# Altium schematic sheet H05R1_Frontend_2.SchDoc(H05R1_Frontend_2)
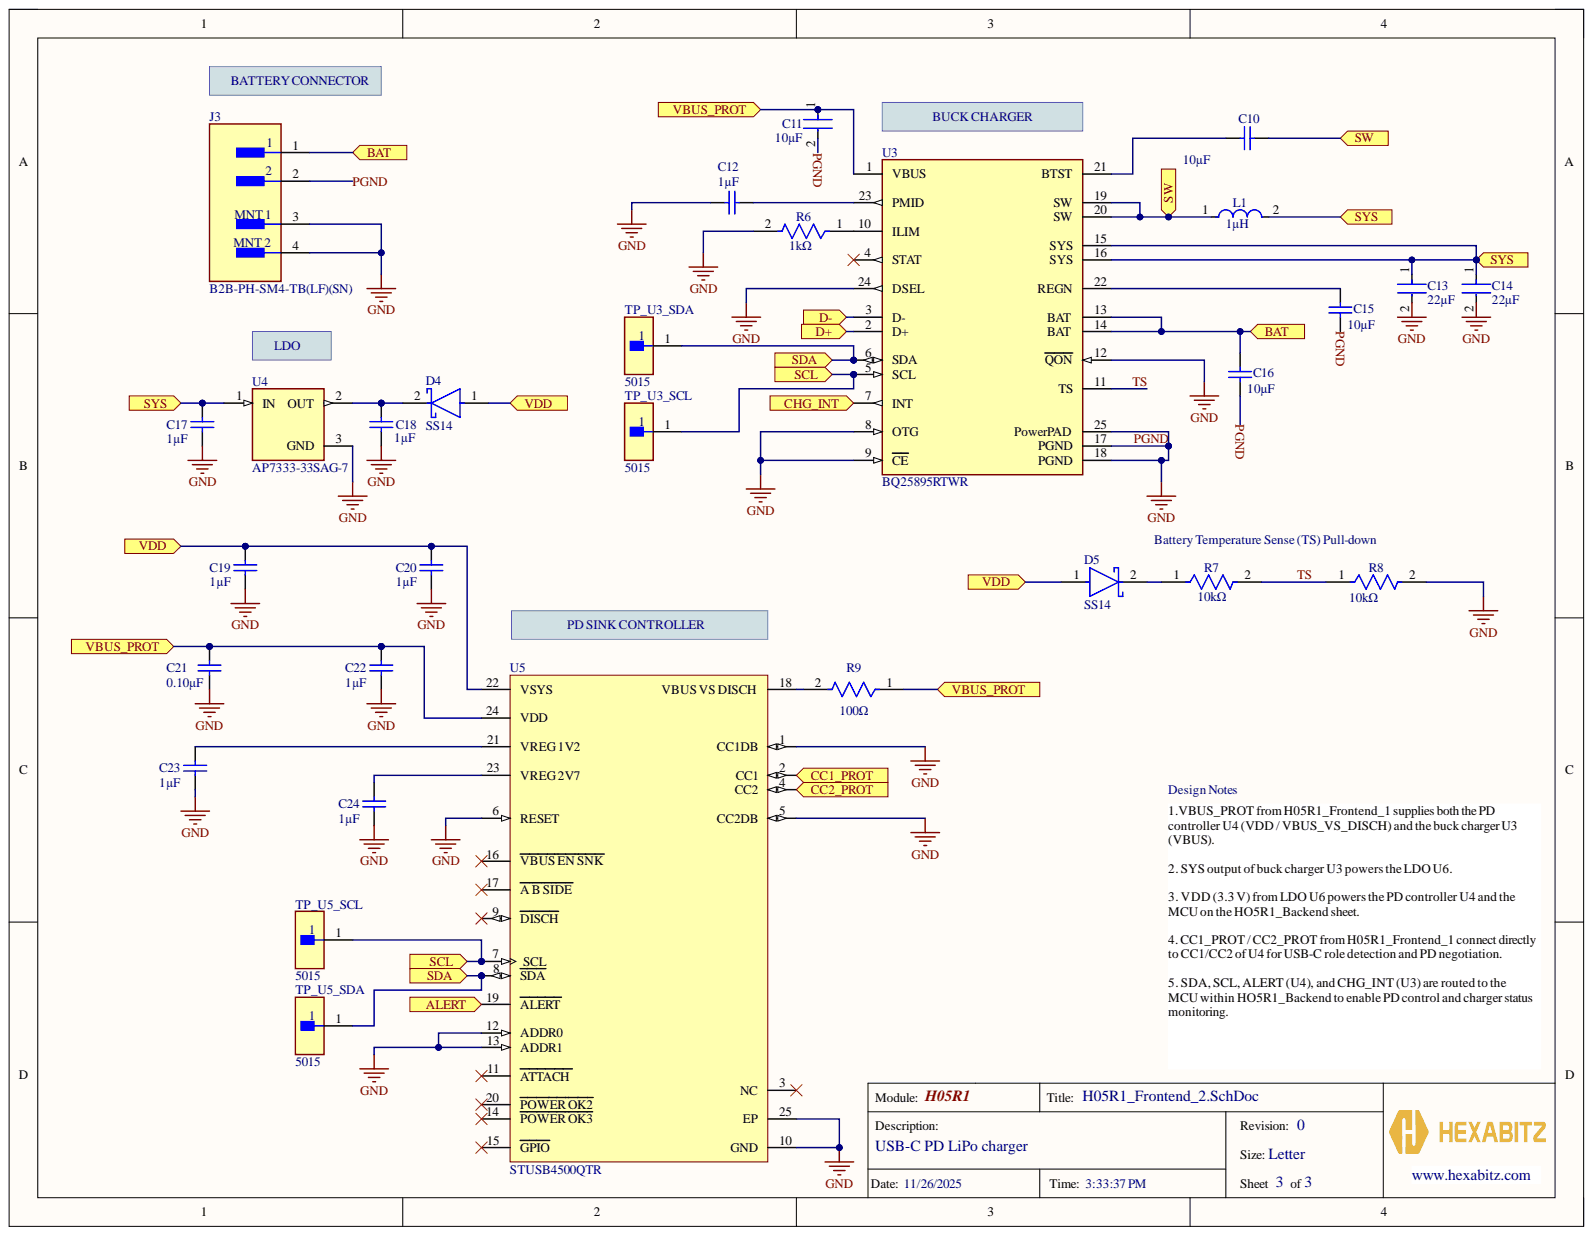
[diagram p0: H05R1_Frontend_2.SchDoc]

## Parts
C10  10µF  [CAPC1608X90X35ML10T15]
C11  10µF  [FP-GRM21B-0_2-e0_2_0_7-IPC_B]
C12  1µF  [CAPC1608X90X35ML10T15]
C13  22µF  [FP-GRM21B-0_15-MFG]
C14  22µF  [FP-GRM21B-0_15-MFG]
C15  10µF  [CAPC1608X90X35ML10T15]
C16  10µF  [CAPC2013X135X45ML10T25]
C17  1µF  [CAPC1608X90X35ML10T15]
C18  1µF  [CAPC1608X90X35ML10T15]
C19  1µF  [MURA-0603_H_0.9_M]
C20  1µF  [CAPC1608X90X35ML10T15]
C21  0.10μF  [CAPC1608X90X35LL10T15]
C22  1µF  [CAPC1608X90X35ML10T15]
C23  1µF  [CAPC1608X90X35ML10T15]
C24  1µF  [CAPC1608X90X35ML10T15]
D4  SS14  [FP-403AE-MFG]
D5  SS14  [FP-403AE-MFG]
J3  B2B-PH-SM4-TB(LF)(SN)  [FP-B2B-PH-SM4-TB_LF_SN-MFG]
L1  1µH  [FP-XAL4020-102MEC-MFG]
R6  1kΩ  [FP-RC0603-0_55-IPC_C]
R7  10kΩ  [FP-RC0603-0_55-IPC_C]
R8  10kΩ  [FP-RC0603-0_55-IPC_C]
R9  100Ω  [FP-RC0603-0_55-MFG]
TP_U3_SCL  5015  [FP-5015-MFG]
TP_U3_SDA  5015  [FP-5015-MFG]
TP_U5_SCL  5015  [FP-5015-MFG]
TP_U5_SDA  5015  [FP-5015-MFG]
U3  BQ25895RTWR  [FP-RTW0024H-IPC_B]
U4  AP7333-33SAG-7  [FP-SOT23-MFG]
U5  STUSB4500QTR  [FP-QFN-24-EP_4x4-MFG]

## Ports
ALERT, BAT, CC1_PROT, CC2_PROT, CHG_INT, D+, D-, SCL, SDA, SW, SYS, VBUS_PROT, VDD

## Nets
ALERT: U5.19(ALERT) ; port ALERT
BAT: C16.2 J3.1 U3.13(BAT) U3.14(BAT) ; port BAT
CC1_PROT: U5.2(CC1) ; port CC1_PROT
CC2_PROT: U5.4(CC2) ; port CC2_PROT
CHG_INT: U3.7(INT) ; port CHG_INT
PGND: C11.2 C12.1 C13.2 C14.2 C15.1 C16.1 C17.1 C18.1 C19.1 C20.1 C21.1 C22.1 C23.1 C24.1 J3.2 J3.3 J3.4 R6.2 R8.2 U3.8(OTG) U3.9(CE) U3.12(QON) U3.17(PGND) U3.18(PGND) U3.24(DSEL) U3.25(PowerPAD) U4.3 U5.1(CC1DB) U5.5(CC2DB) U5.6(RESET) U5.10(GND) U5.12(ADDR0) U5.13(ADDR1) U5.25(EP)
SCL: TP_U3_SCL.1 TP_U5_SCL.1 U3.5(SCL) U5.7(SCL) ; port SCL
SDA: TP_U3_SDA.1 TP_U5_SDA.1 U3.6(SDA) U5.8(SDA) ; port SDA
SW: C10.1 L1.1 U3.19(SW) U3.20(SW) ; port SW
SYS: C13.1 C14.1 C17.2 L1.2 U3.15(SYS) U3.16(SYS) U4.1 ; port SYS
TS: R7.2 R8.1 U3.11(TS)
VBUS_PROT: C11.1 C21.2 C22.2 R9.1 U3.1(VBUS) U5.24(VDD) ; port VBUS_PROT
VDD: C19.2 C20.2 D4.1 D5.1 U5.22(VSYS) ; port VDD
NetC10_2: C10.2 U3.21(BTST)
NetC12_2: C12.2 U3.23(PMID)
NetC15_2: C15.2 U3.22(REGN)
NetC18_2: C18.2 D4.2 U4.2
NetC23_2: C23.2 U5.21(VREG_1V2)
NetC24_2: C24.2 U5.23(VREG_2V7)
NetD5_2: D5.2 R7.1
NetR6_1: R6.1 U3.10(ILIM)
NetR9_2: R9.2 U5.18(VBUS_VS_DISCH)
NetU3_2: U3.2(D+)
NetU3_3: U3.3(D-)

Not connected: U3 4(STAT); U5 3(NC) 9(DISCH) 11(ATTACH) 14(POWER_OK3) 15(GPIO) 16(VBUS_EN_SNK) 17(A_B_SIDE) 20(POWER_OK2)

## Library data of the parts
C10 = CMP-2006-02858-1: Ceramic Capacitor, Multilayer, Ceramic, 25V, 10% +Tol, 10% -Tol, X7R, 15% TC, 10uF, Surface Mount, 0805; Manufacturer: Murata; Part Number: GRM188R71C473KA01D; Component Kind: Standard; Library Name: Altium Content Vault; Octopart: https://octopart.com/part/murata/GRM21BZ71E106KE15L
C11 = CMP-06035-047417-1: Chip Multilayer Ceramic Capacitors for General Purpose, 0805, 10uF, X7R, 15%, 10%, 25V; MPN: GRM21BZ71E106KE15L; MFN: Murata; Component Kind: Standard; Library Name: Altium Content Vault; Parameter 1: https://octopart.com/part/murata/GRM21BZ71E106KE15L
C12 = CMP-2006-02845-1: Ceramic Capacitor, Ceramic, 16V, 10% +Tol, 10% -Tol, X7R, 15% TC, 1uF, Surface Mount, 0603  Series: Murata - Chip Monolithic Ceramic Capacitors; Part Number: GRM188R71C105KA12D; Component Kind: Standard; Library Name: Altium Content Vault; Manufacturer: Murata; Octopart: https://octopart.com/search?q=GRM188R71C105KA12D&currency=USD&specs=0
C13 C14 = CMP-2007-03385-2: Chip Multilayer Ceramic Capacitors for General Purpose, 0805, 22uF, X5R, 15%, 20%, 6.3V; MPN: GRM21BR60J226ME39L; MFN: Murata; Capacitance: 22uF; Case Code (Imperial): 0805; Case Code (Metric): 2012; Case/Package: 0805; Component Kind: Standard; Depth: 1.25mm; Dielectric: X5R; Height: 1.25mm; Lead Free: Lead Free; Length: 2mm; Library Name: Altium Content Vault; Material: Ceramic; Max Operating Temperature: 85°C; Min Operating Temperature: -55°C; Mount: Surface Mount; Octopart: https://octopart.com/part/murata/GRM21BR60J226ME39L; Package Quantity: 1; Packaging: Tape and Reel; Radiation Hardening: No; REACH SVHC: No SVHC; Termination: SMD/SMT; Thickness: 0.053inch; Tolerance: 20%; Voltage: 6.3V; Voltage Rating: 6.3V; Voltage Rating (DC): 6.3V; Width: 0.049inch
C15 = CMP-2006-02845-1: Ceramic Capacitor, Multilayer, Ceramic, 25V, 10% +Tol, 10% -Tol, X7R, 15% TC, 10uF, Surface Mount, 0805; Manufacturer: Murata; Part Number: GRM188R71C105KA12D; Component Kind: Standard; Library Name: Altium Content Vault; Octopart: https://octopart.com/part/murata/GRM21BZ71E106KE15L
C16 = CMP-2007-03529-1: Multilayer Ceramic Capacitors MLCC Surface Mount 0805 10uF 6.3 Volts X5R; Manufacturer: Murata; Part Number: GRM21BR60J106KE19L; Component Kind: Standard; Library Name: Altium Content Vault; Octopart: https://octopart.com/search?q=GRM21BR60J106KE19L&currency=USD&specs=0
C17 C18 C20 = CMP-2006-02845-1: Ceramic Capacitor, Ceramic, 16V, 10% +Tol, 10% -Tol, X7R, 15% TC, 1uF, Surface Mount, 0603; Manufacturer: Murata; Part Number: GRM188R71C105KA12D; Component Kind: Standard; Library Name: Altium Content Vault; Octopart: https://octopart.com/part/murata/GRM188R71C105KA12D
C19 = CMP-2000-05253-1: CAP, Ceramic, 0.1 uF, 10%, 16 V, 0603, RoHS, Tape and Reel; Manufacturer: Murata; MPN: GRM188R71C104KA01D; Brand: MurataElectronics; Capacitance: 0.1uF; Capacitance - nF: 100nF; Capacitance - pF: 100000pF; Case Height: 0.8mm; Case Length: 1.6mm; Dielectric: X7R; Temperature Coefficient / Code: +/-15%; Type: GeneralPurposeMLCC; Voltage Rating: 16V; Case Code - in: 0603; Case Code - mm: 1608; Case Width: 0.8mm; Component Kind: Standard; Datasheet URL: https://datasheet.ciiva.com/29202/451467-da-01-en-vs-kondensator-0603-29202287.pdf; Datasheet Version: Dec-10; Dimensions: 0.8mmWx1.6mmLx0.8mmH; Factory Pack Quantity: 4000; Library Name: Altium Content Vault; Manufacturer URL: http://www.murata.com/; Maximum Operating Temperature: +125C; Minimum Operating Temperature: -55C; Octopart: https://octopart.com/part/murata/GRM188R71C104KA01D; Operating Temperature Range: -55Cto+125C; Package / Case: 0603(1608metric); Packaging: Reel; Product: GeneralTypeMLCCs; Product Category: MultilayerCeramicCapacitorsMLCC-SMD/SMT; RoHS: Details; Series: GRM; Termination Style: SMD/SMT; Tolerance: 10%; Voltage Rating DC: 16V
C21 = CMP-2006-02868-1: 25V 100Nf X7R 10% 0603 Multilayer Ceramic Capacitors Mlcc Smd/Smt Rohs; Manufacturer: Murata; Part Number: GRM188R71E104KA01D; Component Kind: Standard; Library Name: Altium Content Vault
C22 = CMP-2006-02460-1: Manufacturer: Murata; Part Number: GRM188R71E105KA12D; Component Kind: Standard; Library Name: Altium Content Vault; Octopart: https://octopart.com/part/murata/GRM188R71E105KA12D
C23 C24 = CMP-2006-02460-1: Ceramic Capacitor, Ceramic, 25V, 10% +Tol, 10% -Tol, X7R, 15% TC, 1uF, Surface Mount, 0603; Manufacturer: Murata; Part Number: GRM188R71E105KA12D; Component Kind: Standard; Library Name: Altium Content Vault; Octopart: https://octopart.com/part/murata/GRM188R71E105KA12D
D4 D5 = CMP-2000-04943-2: DIODE SCHOTTKY 40V 1A SMA; Manufacturer: ON Semiconductor; Max Junction Temp: +125°C; Max Surge Forward Current: 40A; Peak Reverse Current: 0.2mA; Standoff Height: 0.05mm; Automotive: No; Category: Diode; Component Kind: Standard; ComponentLink2Description: Datasheet; ComponentLink2URL: https://www.onsemi.com/pub/Collateral/SS19-D.PDF; Device Class L1: Discrete Semiconductors; Device Class L2: Diodes; Device Class L3: Schottky Diodes; Digikey Description: DIODE SCHOTTKY 40V 1A SMA; Forward Current: 1A; Height: 2.5mm; Lead Free: Yes; Library Name: Altium Content Vault; Manufacturer Part Number: SS14; Max Repetitive Reverse Voltage: 40V; Mouser Description: Schottky Diodes & Rectifiers SMA 40V 1.0A; Octopart: https://octopart.com/ss14-on+semiconductor-29205033; Package: 403AE; RoHS: TRUE; Temperature: -65°C to +125°C; Temperature Range High: +125°C; Temperature Range Low: -65°C
J3 = CMP-2000-05163-2: CONN HEADER SMD 2POS 2MM; Contact Resistance: 20mR; Current Rating: 2A; Gender: Male; Insulation Resistance: 1GR; Mated Stacking Heights: 8.6mm; Max Voltage Rating (AC): 100V; MFN: JST; Number of Contacts: 2; Color: White; Component Kind: Standard; Connector Type: Header; Contact Finish - Mating: TIN; Contact Material: Copper Alloy; Contact Mating Length: 0.13inch; Contact Plating: Tin; Contact Shape: Square; Contact Type: Male Pin; Depth: 5mm; Fastening Type: Detent; Flammability Rating: UL94 V-0; Height: 9.4mm; Housing Color: Beige; Housing Material: Polyamide; Insulation Color: Natural; Insulation Material: Nylon; Lead Free: Lead Free; Lead Pitch: 2mm; Length: 7.95mm; Library Name: Altium Content Vault; Max Current Rating: 2A; Max Operating Temperature: 85°C; Min Operating Temperature: -25°C; Mount: Surface Mount; MPN: B2B-PH-SM4-TB(LF)(SN); Number of Poles: 2; Number of Positions: 2; Number of Rows: 1; Octopart: https://octopart.com/part/jst/B2B-PH-SM4-TB%28LF%29%28SN%29; Orientation: Straight; Packaging: Tape and Reel; Pitch: 2mm; REACH SVHC: No SVHC; Series: PH; Termination: Solder; Voltage Rating: 100V; Voltage Rating (AC): 100V; Width: 5.5mm; Working Voltage: 100V
L1 = CMP-11268-000037-1: Fixed Inductors 1uH 20% 9.6A 14.60mOhms AEC-Q200; Manufacturer: Coilcraft; Manufacturer Part Number: XAL4020-102MEC; Automotive Grade: Grade 1; Core Material: Composite; Saturation Current: 8.7A; Self Resonant Frequency: 79MHz; Series Resistance: 14.6mΩ; Shielding: true; Standoff Height: 0.1mm; Test Frequency: 1MHz; Ambient Temperature Range High: +125°C; Ambient Temperature Range Low: -40°C; Automotive: Yes; Category: Ind; Component Kind: Standard; ComponentLink2Description: Datasheet; ComponentLink2URL: https://www.coilcraft.com/pdfs/xal4000.pdf; Composition: Wirewound; Device Class L1: Passive Components; Device Class L2: Inductors; Device Class L3: Fixed Inductors; Height: 2.1mm; Inductance: 1uH; Lead Free: Yes; Library Name: Altium Content Vault; Mouser Description: Power inductor, shielded, 20% tol, SMT, RoHS, halogen f; Octopart: https://octopart.com/xal4020-102mec-coilcraft-13142161; Package: 0; Rms Current: 9.6A; RoHS: TRUE; Tolerance: 20%; Value: 1uH
R6 = CMP-1659-00009-5: RES 1K OHM 1% 1/10W 0603; MPN: RC0603FR-071KL; Max Power Dissipation: 100mW; MFN: Yageo Group; Resistance: 1kR; Temperature Coefficient: 100ppm/°C; Voltage Rating: 50V; Case Code (Imperial): 0603; Case Code (Metric): 1608; Case/Package: 0603; Component Kind: Standard; Construction: Rectangular; Contact Plating: Tin; Depth: 0.8mm; Features: Moisture Resistant; Height: 0.45mm; Lead Free: Lead Free; Length: 1.6mm; Library Name: Altium Content Vault; Max Operating Temperature: 155°C; Military Standard: Not; Min Operating Temperature: -55°C; Number of Terminations: 2; Packaging: Tape and Reel; Power Rating: 100mW; Radiation Hardening: No; REACH SVHC: No SVHC; Resistance Tolerance: 1%; Resistor Type: Thick Film; RoHS Compliant: Yes; Series: RC; Size Code: 0603; Technology: THICK FILM; Termination: SMD/SMT; Tolerance: 1%
R7 R8 = CMP-2100-03664-2: RES 10K OHM 1% 1/10W 0603; MPN: RC0603FR-0710KL; Max Power Dissipation: 100mW; MFN: Yageo Group; Resistance: 10kR; Temperature Coefficient: 100ppm/°C; Voltage Rating: 75V; Voltage Rating (DC): 50V; Case Code (Imperial): 0603; Case Code (Metric): 1608; Case/Package: 0603; Component Kind: Standard; Construction: Rectangular; Depth: 0.8mm; Features: Moisture Resistant; Height: 0.45mm; Lead Free: Lead Free; Lead Pitch: 0.3mm; Length: 1.6mm; Library Name: Altium Content Vault; Max Operating Temperature: 155°C; Military Standard: Not; Min Operating Temperature: -55°C; Number of Pins: 2; Number of Terminations: 2; Octopart: https://octopart.com/search?q=RC0603FR-0710KL&currency=USD&specs=0; Packaging: Tape and Reel; Power Rating: 100mW; Resistance Tolerance: 1%; Resistor Type: Thick Film; RoHS Compliant: Yes; Series: RC; Size Code: 0603; Termination: SMD/SMT; Tolerance: 1%; Weight: 7.1E-05oz; Width: 0.8mm
R9 = CMP-2100-03663-2: MPN: RC0603FR-07100RL; NexarPartId: 55402879; MFN: Yageo Group; Power Rating: 100mW; Temperature Coefficient: 100ppm/°C; Tolerance: 1%; Voltage Rating: 50V; Case Code (Imperial): 0603; Case Code (Metric): 0603; Case/Package: 0603; Component Kind: Standard; Composition: Thick Film; Depth: 800µm; Height: 450µm; Lead Free: Lead Free; Length: 1.6mm; Library Name: Altium Content Vault; Max Operating Temperature: 155°C; Military Standard: Not; Min Operating Temperature: -55°C; Number of Pins: 2; Packaging: Tape & Reel; Parameter 1: RC0603FR-07100RL; REACH SVHC: Unknown; Resistance: 100Ω; RoHS: Compliant; Schedule B: 8533210045, 8533210045; Termination: SMD/SMT; Voltage Rating (DC): 50V; Width: 800µm
TP_U3_SCL TP_U3_SDA TP_U5_SCL TP_U5_SDA = CMP-2000-05183-2: PC TEST POINT MINIATURE; Manufacturer: keystone; Conn Orientation: Straight; Number Of Contacts: 1; Automotive: No; Category: Conn; Component Kind: Standard; ComponentLink2Description: Datasheet; ComponentLink2URL: http://www.keyelco.com/product-pdf.cfm?p=1353; Contact Material: Silver; Device Class L1: Connectors; Device Class L2: unset; Device Class L3: unset; Digikey Description: PC TEST POINT MINIATURE; Height: 1.65mm; Lead Free: Yes; Library Name: Altium Content Vault; Manufacturer Part Number: 5015; Octopart: https://octopart.com/5015-keystone-3822; Package: 5015; RoHS: TRUE
U3 = CMP-04911-000061-1: IC NVDC CHARGER I2C 24WQFN; MFN: Texas Instruments; Number of Cells: 1; Operating Supply Voltage: 14V; Output Current: 5A; Output Voltage: 4.6V; Component Kind: Standard; Contact Plating: Gold; Lead Free: Lead Free; Library Name: Altium Content Vault; Max Operating Temperature: 85°C; Min Operating Temperature: -40°C; Mount: Surface Mount; MPN: BQ25895RTWR; Octopart: https://octopart.com/bq25895rtwr-texas+instruments-56801238; RoHS Compliant: Yes
U4 = CMP-12756-000020-1: IC REG LINEAR 3.3V 300MA SOT23-3; Manufacturer: Diodes Inc.; Accuracy Percentage: 2%; Dropout Voltage: 300mV; Max Junction Temp: +125°C; Max Power Dissipation: 600mW; Max Supply Voltage: 6V; Min Supply Voltage: 2V; Nominal Supply Current: 55-65uA; Number Of Outputs: 1; Output Type: Fixed; Standoff Height: 0.013mm; Ambient Temperature Range High: +85°C; Ambient Temperature Range Low: -40°C; Automotive: No; Category: IC; Component Kind: Standard; ComponentLink2Description: Datasheet; ComponentLink2URL: http://datasheet.octopart.com/AP7333-33SAG-7-Diodes-Inc.-datasheet-11745883.pdf; Device Class L1: Integrated Circuits (ICs); Device Class L2: Power Management ICs; Device Class L3: Voltage Regulators - Linear; Digikey Description: IC REG LINEAR 3.3V 300MA SOT23-3; Footprint Url: https://www.diodes.com/assets/Package-Files/SOT23.pdf; Height: 1.1mm; Ipc Land Pattern Name: SOT92P240X102-3; Lead Free: Yes; Library Name: Altium Content Vault; Manufacturer Part Number: AP7333-33SAG-7; Mouser Description: AP7333 Series 3.3 V 300 mA SMT Low Dropout Linear Regulator - SOT-23-3; Octopart: https://octopart.com/ap7333-33sag-7-diodes+inc.-17947531; Output Current: 300mA; Output Voltage: 3.3V; Package: SOT23; RoHS: TRUE; Temperature: -40°C to +125°C; Temperature Range High: +125°C; Temperature Range Low: -40°C
U5 = CMP-12113-000003-3: 4.1V~22V USB 2.0 I2C Controllers Interface ICs STUSB45 Controller 24-VFQFN Exposed Pad; Interface: I2C, USB; Max Junction Temperature (Tj): 145°C; Max Supply Voltage: 22V; MFN: STMicroelectronics; Min Supply Voltage: 3V; Number of Ports: 1; USB Standard: USB 2.0; Ambient Temperature Range High: 105°C; Component Kind: Standard; Height: 1mm; Library Name: Altium Content Vault; Lifecycle Status: Production; Max Operating Temperature: 105°C; Min Operating Temperature: -40°C; MPN: STUSB4500QTR; Octopart: https://octopart.com/stusb4500qtr-stmicroelectronics-91765108; Schedule B: 8542390000, 8542390000
